# Altium schematic sheet LED.SchDoc
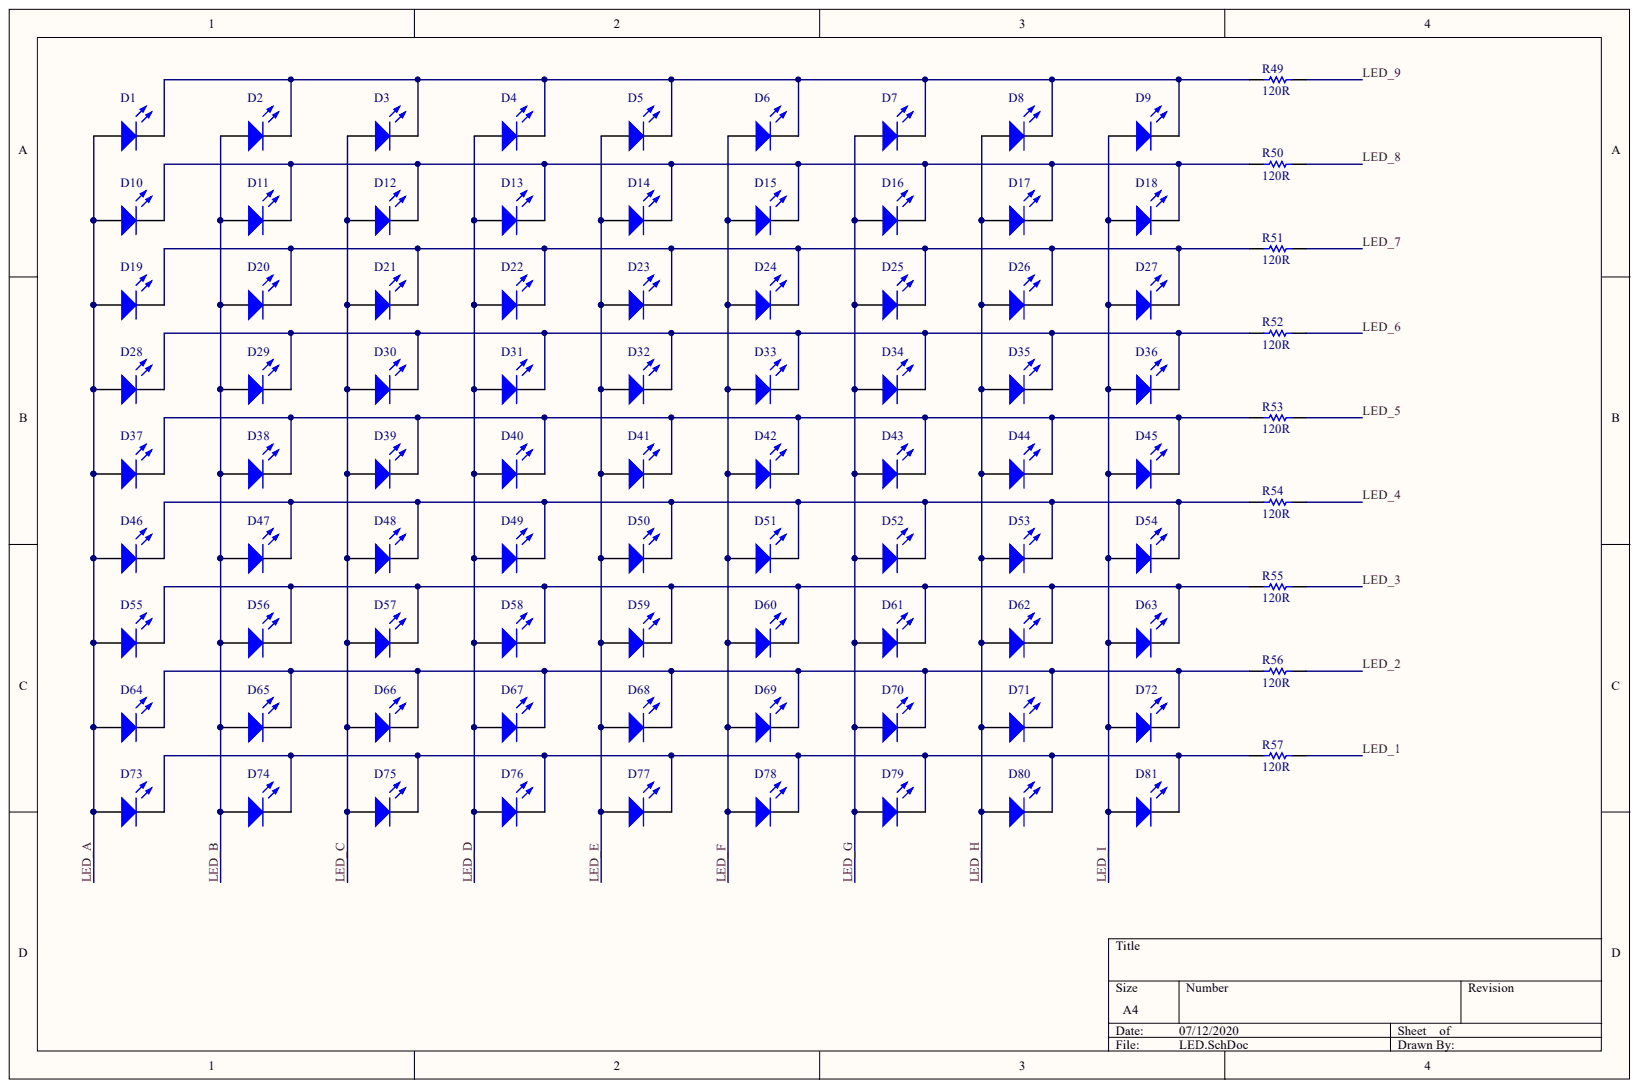
[diagram p0: LED.SchDoc]

## Parts
D1  LED  [PCB-te7tz55drqxqj78i57nm-1]  (value not drawn)
D2  LED  [PCB-te7tz55drqxqj78i57nm-1]  (value not drawn)
D3  LED  [PCB-te7tz55drqxqj78i57nm-1]  (value not drawn)
D4  LED  [PCB-te7tz55drqxqj78i57nm-1]  (value not drawn)
D5  LED  [PCB-te7tz55drqxqj78i57nm-1]  (value not drawn)
D6  LED  [PCB-te7tz55drqxqj78i57nm-1]  (value not drawn)
D7  LED  [PCB-te7tz55drqxqj78i57nm-1]  (value not drawn)
D8  LED  [PCB-te7tz55drqxqj78i57nm-1]  (value not drawn)
D9  LED  [PCB-te7tz55drqxqj78i57nm-1]  (value not drawn)
D10  LED  [PCB-te7tz55drqxqj78i57nm-1]  (value not drawn)
D11  LED  [PCB-te7tz55drqxqj78i57nm-1]  (value not drawn)
D12  LED  [PCB-te7tz55drqxqj78i57nm-1]  (value not drawn)
D13  LED  [PCB-te7tz55drqxqj78i57nm-1]  (value not drawn)
D14  LED  [PCB-te7tz55drqxqj78i57nm-1]  (value not drawn)
D15  LED  [PCB-te7tz55drqxqj78i57nm-1]  (value not drawn)
D16  LED  [PCB-te7tz55drqxqj78i57nm-1]  (value not drawn)
D17  LED  [PCB-te7tz55drqxqj78i57nm-1]  (value not drawn)
D18  LED  [PCB-te7tz55drqxqj78i57nm-1]  (value not drawn)
D19  LED  [PCB-te7tz55drqxqj78i57nm-1]  (value not drawn)
D20  LED  [PCB-te7tz55drqxqj78i57nm-1]  (value not drawn)
D21  LED  [PCB-te7tz55drqxqj78i57nm-1]  (value not drawn)
D22  LED  [PCB-te7tz55drqxqj78i57nm-1]  (value not drawn)
D23  LED  [PCB-te7tz55drqxqj78i57nm-1]  (value not drawn)
D24  LED  [PCB-te7tz55drqxqj78i57nm-1]  (value not drawn)
D25  LED  [PCB-te7tz55drqxqj78i57nm-1]  (value not drawn)
D26  LED  [PCB-te7tz55drqxqj78i57nm-1]  (value not drawn)
D27  LED  [PCB-te7tz55drqxqj78i57nm-1]  (value not drawn)
D28  LED  [PCB-te7tz55drqxqj78i57nm-1]  (value not drawn)
D29  LED  [PCB-te7tz55drqxqj78i57nm-1]  (value not drawn)
D30  LED  [PCB-te7tz55drqxqj78i57nm-1]  (value not drawn)
D31  LED  [PCB-te7tz55drqxqj78i57nm-1]  (value not drawn)
D32  LED  [PCB-te7tz55drqxqj78i57nm-1]  (value not drawn)
D33  LED  [PCB-te7tz55drqxqj78i57nm-1]  (value not drawn)
D34  LED  [PCB-te7tz55drqxqj78i57nm-1]  (value not drawn)
D35  LED  [PCB-te7tz55drqxqj78i57nm-1]  (value not drawn)
D36  LED  [PCB-te7tz55drqxqj78i57nm-1]  (value not drawn)
D37  LED  [PCB-te7tz55drqxqj78i57nm-1]  (value not drawn)
D38  LED  [PCB-te7tz55drqxqj78i57nm-1]  (value not drawn)
D39  LED  [PCB-te7tz55drqxqj78i57nm-1]  (value not drawn)
D40  LED  [PCB-te7tz55drqxqj78i57nm-1]  (value not drawn)
D41  LED  [PCB-te7tz55drqxqj78i57nm-1]  (value not drawn)
D42  LED  [PCB-te7tz55drqxqj78i57nm-1]  (value not drawn)
D43  LED  [PCB-te7tz55drqxqj78i57nm-1]  (value not drawn)
D44  LED  [PCB-te7tz55drqxqj78i57nm-1]  (value not drawn)
D45  LED  [PCB-te7tz55drqxqj78i57nm-1]  (value not drawn)
D46  LED  [PCB-te7tz55drqxqj78i57nm-1]  (value not drawn)
D47  LED  [PCB-te7tz55drqxqj78i57nm-1]  (value not drawn)
D48  LED  [PCB-te7tz55drqxqj78i57nm-1]  (value not drawn)
D49  LED  [PCB-te7tz55drqxqj78i57nm-1]  (value not drawn)
D50  LED  [PCB-te7tz55drqxqj78i57nm-1]  (value not drawn)
D51  LED  [PCB-te7tz55drqxqj78i57nm-1]  (value not drawn)
D52  LED  [PCB-te7tz55drqxqj78i57nm-1]  (value not drawn)
D53  LED  [PCB-te7tz55drqxqj78i57nm-1]  (value not drawn)
D54  LED  [PCB-te7tz55drqxqj78i57nm-1]  (value not drawn)
D55  LED  [PCB-te7tz55drqxqj78i57nm-1]  (value not drawn)
D56  LED  [PCB-te7tz55drqxqj78i57nm-1]  (value not drawn)
D57  LED  [PCB-te7tz55drqxqj78i57nm-1]  (value not drawn)
D58  LED  [PCB-te7tz55drqxqj78i57nm-1]  (value not drawn)
D59  LED  [PCB-te7tz55drqxqj78i57nm-1]  (value not drawn)
D60  LED  [PCB-te7tz55drqxqj78i57nm-1]  (value not drawn)
D61  LED  [PCB-te7tz55drqxqj78i57nm-1]  (value not drawn)
D62  LED  [PCB-te7tz55drqxqj78i57nm-1]  (value not drawn)
D63  LED  [PCB-te7tz55drqxqj78i57nm-1]  (value not drawn)
D64  LED  [PCB-te7tz55drqxqj78i57nm-1]  (value not drawn)
D65  LED  [PCB-te7tz55drqxqj78i57nm-1]  (value not drawn)
D66  LED  [PCB-te7tz55drqxqj78i57nm-1]  (value not drawn)
D67  LED  [PCB-te7tz55drqxqj78i57nm-1]  (value not drawn)
D68  LED  [PCB-te7tz55drqxqj78i57nm-1]  (value not drawn)
D69  LED  [PCB-te7tz55drqxqj78i57nm-1]  (value not drawn)
D70  LED  [PCB-te7tz55drqxqj78i57nm-1]  (value not drawn)
D71  LED  [PCB-te7tz55drqxqj78i57nm-1]  (value not drawn)
D72  LED  [PCB-te7tz55drqxqj78i57nm-1]  (value not drawn)
D73  LED  [PCB-te7tz55drqxqj78i57nm-1]
D74  LED  [PCB-te7tz55drqxqj78i57nm-1]
D75  LED  [PCB-te7tz55drqxqj78i57nm-1]
D76  LED  [PCB-te7tz55drqxqj78i57nm-1]
D77  LED  [PCB-te7tz55drqxqj78i57nm-1]
D78  LED  [PCB-te7tz55drqxqj78i57nm-1]
D79  LED  [PCB-te7tz55drqxqj78i57nm-1]
D80  LED  [PCB-te7tz55drqxqj78i57nm-1]
D81  LED  [PCB-te7tz55drqxqj78i57nm-1]
R49  120R  [RESC1005X04M]
R50  120R  [RESC1005X04M]
R51  120R  [RESC1005X04M]
R52  120R  [RESC1005X04M]
R53  120R  [RESC1005X04M]
R54  120R  [RESC1005X04M]
R55  120R  [RESC1005X04M]
R56  120R  [RESC1005X04M]
R57  120R  [RESC1005X04M]

## Nets
LED_1: R57.1
LED_2: R56.1
LED_3: R55.1
LED_4: R54.1
LED_5: R53.1
LED_6: R52.1
LED_7: R51.1
LED_8: R50.1
LED_9: R49.1
LED_A: D1.1 D10.1 D19.1 D28.1 D37.1 D46.1 D55.1 D64.1 D73.1
LED_B: D2.1 D11.1 D20.1 D29.1 D38.1 D47.1 D56.1 D65.1 D74.1
LED_C: D3.1 D12.1 D21.1 D30.1 D39.1 D48.1 D57.1 D66.1 D75.1
LED_D: D4.1 D13.1 D22.1 D31.1 D40.1 D49.1 D58.1 D67.1 D76.1
LED_E: D5.1 D14.1 D23.1 D32.1 D41.1 D50.1 D59.1 D68.1 D77.1
LED_F: D6.1 D15.1 D24.1 D33.1 D42.1 D51.1 D60.1 D69.1 D78.1
LED_G: D7.1 D16.1 D25.1 D34.1 D43.1 D52.1 D61.1 D70.1 D79.1
LED_H: D8.1 D17.1 D26.1 D35.1 D44.1 D53.1 D62.1 D71.1 D80.1
LED_I: D9.1 D18.1 D27.1 D36.1 D45.1 D54.1 D63.1 D72.1 D81.1
NetD1_2: D1.2 D2.2 D3.2 D4.2 D5.2 D6.2 D7.2 D8.2 D9.2 R49.2
NetD10_2: D10.2 D11.2 D12.2 D13.2 D14.2 D15.2 D16.2 D17.2 D18.2 R50.2
NetD19_2: D19.2 D20.2 D21.2 D22.2 D23.2 D24.2 D25.2 D26.2 D27.2 R51.2
NetD28_2: D28.2 D29.2 D30.2 D31.2 D32.2 D33.2 D34.2 D35.2 D36.2 R52.2
NetD37_2: D37.2 D38.2 D39.2 D40.2 D41.2 D42.2 D43.2 D44.2 D45.2 R53.2
NetD46_2: D46.2 D47.2 D48.2 D49.2 D50.2 D51.2 D52.2 D53.2 D54.2 R54.2
NetD55_2: D55.2 D56.2 D57.2 D58.2 D59.2 D60.2 D61.2 D62.2 D63.2 R55.2
NetD64_2: D64.2 D65.2 D66.2 D67.2 D68.2 D69.2 D70.2 D71.2 D72.2 R56.2
NetD73_2: D73.2 D74.2 D75.2 D76.2 D77.2 D78.2 D79.2 D80.2 D81.2 R57.2

## Library data of the parts
D1-D81 = CMP-bgro2lrssymxwayciwvq-4: bottom biew LED footprint for manual partial drilling. Min distance is 2.2mm. Drill 2.4mm; Component Kind: Standard; Library Name: CommunityVault
R49-R57 = CMP-v99hy84itq0asdedzg70-1: 0402 120R 5%; Body Height: 0.35mm; Body Length: 1mm; Body Width: 0.5mm; Package (Imperial): 0402; Package (Metric): 01005; Resistance: 10K?; Tolerance: 1%; Component Kind: Standard; CustomComponentID: v99hy84itq0asdedzg70; Library Name: CommunityVault
